# Altium schematic sheet Main.SchDoc
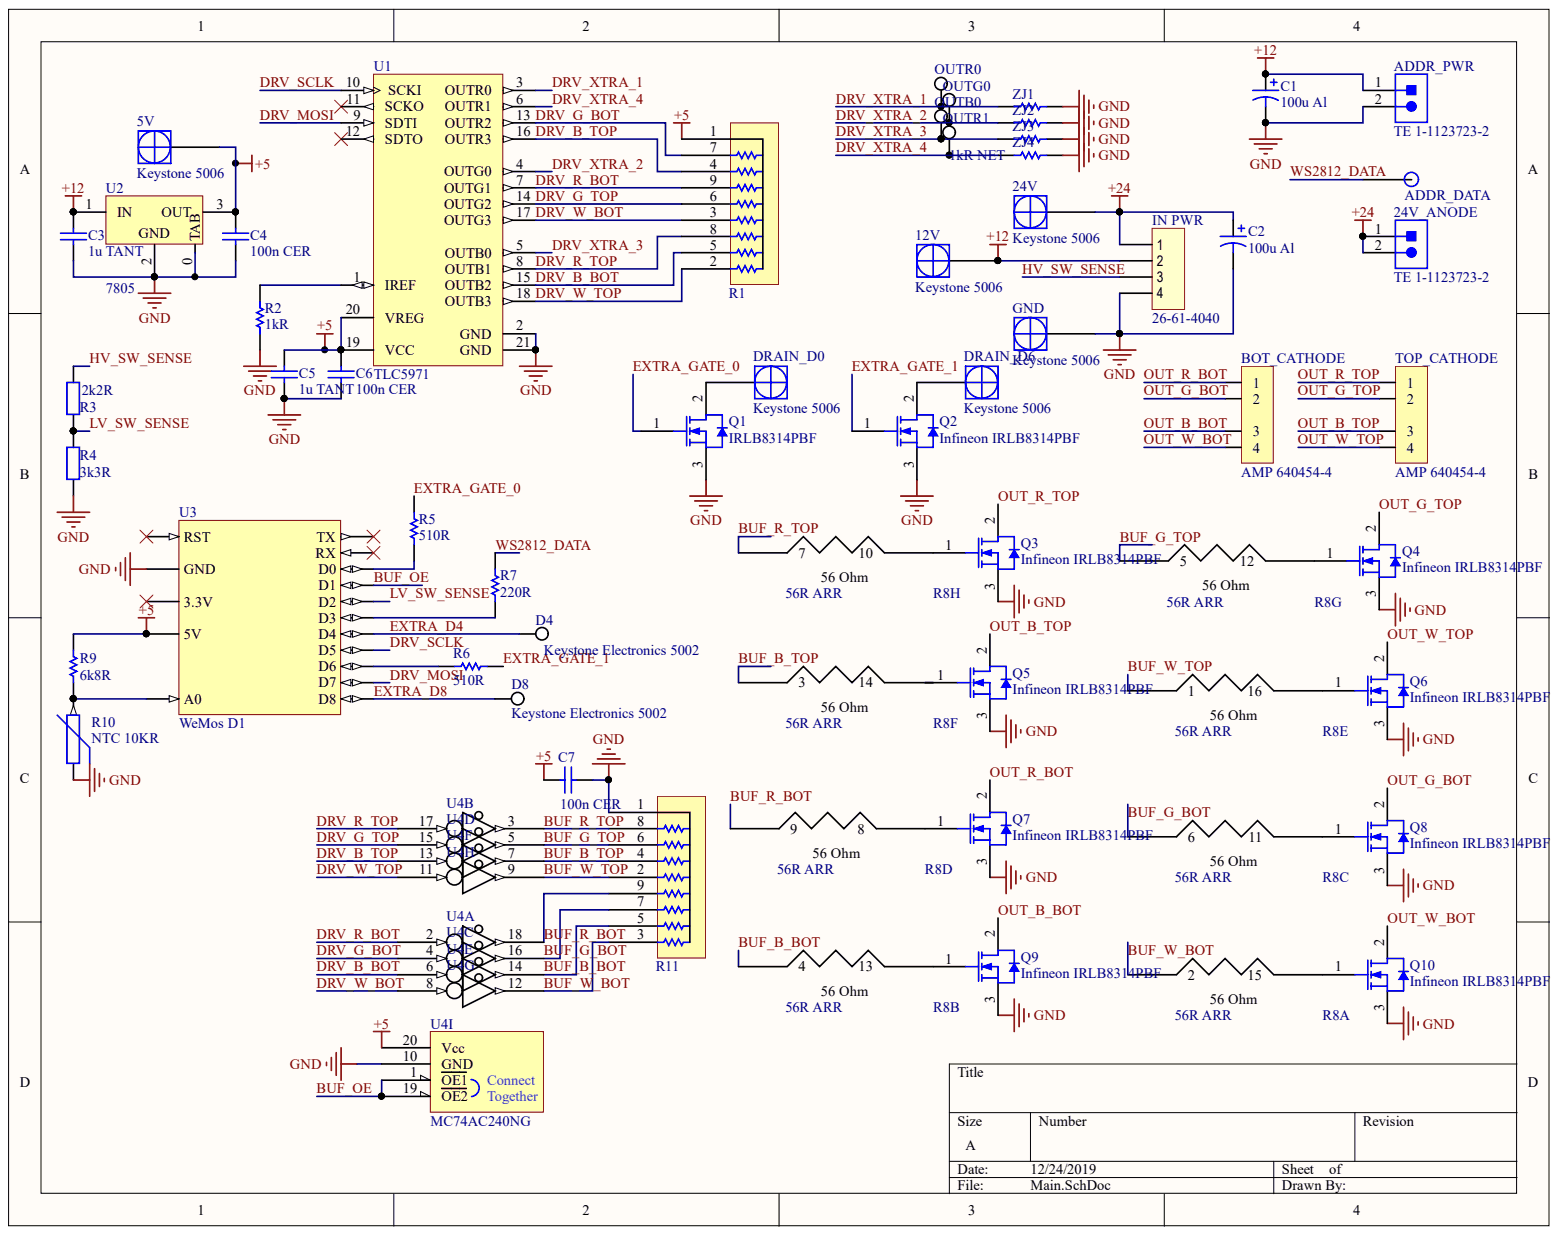
[diagram p0: Main.SchDoc]

## Parts
5V  Keystone 5006  Manufacturer Part Number: 5006  [PCB-kysbc4wefolcrxi0c60k-1]
12V  Keystone 5006  Manufacturer Part Number: 5006  [PCB-kysbc4wefolcrxi0c60k-1]
24V  Keystone 5006  Manufacturer Part Number: 5006  [PCB-kysbc4wefolcrxi0c60k-1]
24V_ANODE  TE 1-1123723-2  [1-1123723-2]
ADDR_DATA  Mill-Max 4357-0-00-15-00-00-03-0  [PCB-uwgrkp67w8sg7bsz95jd-1]  (value not drawn)
ADDR_PWR  TE 1-1123723-2  [1-1123723-2]
BOT_CATHODE  AMP 640454-4  Manufacturer Part Number: 640454-4  [PCBComponent_1]
C1  100u Al  [PCB-ccgi9bhgzt5wnre74bpr-1]
C2  100u Al  [PCB-ccgi9bhgzt5wnre74bpr-1]
C3  1u TANT  [PCB-123082-1]
C4  100n CER  [PCB-ql28fbuo3tond8refhb5-1]
C5  1u TANT  [PCB-123082-1]
C6  100n CER  [PCB-ql28fbuo3tond8refhb5-1]
C7  100n CER  [PCB-ql28fbuo3tond8refhb5-1]
D4  Keystone Electronics 5002  Manufacturer: Keystone  [TEST_LOOP]
D8  Keystone Electronics 5002  Manufacturer: Keystone  [TEST_LOOP]
DRAIN_D0  Keystone 5006  [PCB-kysbc4wefolcrxi0c60k-1]
DRAIN_D6  Keystone 5006  [PCB-kysbc4wefolcrxi0c60k-1]
GND  Keystone 5006  Manufacturer Part Number: 5006  [PCB-kysbc4wefolcrxi0c60k-1]
IN_PWR  26-61-4040  [PCB-nk55arxo024y8e91wffq-1]
OUTB0  (no value)  [TEST_LOOP]
OUTG0  (no value)  [TEST_LOOP]
OUTR0  (no value)  [TEST_LOOP]
OUTR1  (no value)  [TEST_LOOP]
Q1  IRLB8314PBF  [PCB-s29nlaacffy4qzcyhtz9-1]
Q2  Infineon IRLB8314PBF  [PCB-s29nlaacffy4qzcyhtz9-1]
Q3  Infineon IRLB8314PBF  [PCB-s29nlaacffy4qzcyhtz9-1]
Q4  Infineon IRLB8314PBF  [PCB-s29nlaacffy4qzcyhtz9-1]
Q5  Infineon IRLB8314PBF  [PCB-s29nlaacffy4qzcyhtz9-1]
Q6  Infineon IRLB8314PBF  [PCB-s29nlaacffy4qzcyhtz9-1]
Q7  Infineon IRLB8314PBF  [PCB-s29nlaacffy4qzcyhtz9-1]
Q8  Infineon IRLB8314PBF  [PCB-s29nlaacffy4qzcyhtz9-1]
Q9  Infineon IRLB8314PBF  [PCB-s29nlaacffy4qzcyhtz9-1]
Q10  Infineon IRLB8314PBF  [PCB-s29nlaacffy4qzcyhtz9-1]
R1  1kR NET  [PCB-g7s6cxysuoavmae2c56c-1]  (value not drawn)
R2  1kR  [PCB-bfjjg625tt0lscyu35rt-1]
R3  2K2R  [PCB-vee0c8q2g5btr8l8p592-1]
R4  3k3R  [PCB-mh9qeq81pbhpmiehun8l-1]
R5  510R  [PCB-2vcm05y6z7k0bu81myip-1]
R6  510R  [PCB-2vcm05y6z7k0bu81myip-1]
R7  220R  [PCB-heukol1j5k5ii1momt5n-1]
R8  56R ARR  [PCB-pr3f4dgy3c6tti4ikzhc]  (parts A,B,C,D,E,F,G,H)
R9  6k8R  [PCB-2039534-1]
R10  NTC 10KR  [PCB-newt7ey5aqhahc8c8q3h-1]
R11  10kR NET  [PCB-g7s6cxysuoavmae2c56c-1]  (value not drawn)
TOP_CATHODE  AMP 640454-4  Manufacturer Part Number: 640454-4  [PCBComponent_1]
U1  TLC5971  [TI-PWP20-2965X2290TP_N]
U2  7805  [PCBComponent_1 - duplicate]
U3  WeMos D1  [PCB-id05qy3v9mgzaped91p3-1]
U4  MC74AC240NG  [PCB-ssvtan231k94nl4fawkc-1]  (parts A,B,C,D,E,F,G,H,I)
ZJ1  Res1  [PCB-00vg8uwyzhdftjjrlpsr-1]  (value not drawn)
ZJ2  Res1  [PCB-00vg8uwyzhdftjjrlpsr-1]  (value not drawn)
ZJ3  Res1  [PCB-00vg8uwyzhdftjjrlpsr-1]  (value not drawn)
ZJ4  Res1  [PCB-00vg8uwyzhdftjjrlpsr-1]  (value not drawn)

## Nets
+5: 5V.1 C4.2 C5.2 C6.2 C7.2 R1.1 R9.2 U1.19(VCC) U1.20(VREG) U2.3(OUT) U3.9(5V) U4.20(Vcc)
+12: 12V.1 ADDR_PWR.1 C1.1 C3.2 IN_PWR.2 U2.1(IN)
+24: 24V.1 24V_ANODE.1 24V_ANODE.2 C2.1 IN_PWR.1
GND: ADDR_PWR.2 C1.2 C2.2 C3.1 C4.1 C5.1 C6.1 C7.1 GND.1 IN_PWR.4 Q1.3 Q2.3 Q3.3 Q4.3 Q5.3 Q6.3 Q7.3 Q8.3 Q9.3 Q10.3 R2.1 R4.1 R10.1 R11.1 U1.2(GND) U1.21(GND) U2.0(TAB) U2.2 U3.10(GND) U4.10(GND) ZJ1.2 ZJ2.2 ZJ3.2 ZJ4.2
BUF_B_BOT: R8.4 R11.5 U4.14
BUF_B_TOP: R8.3 R11.4 U4.7
BUF_G_BOT: R8.6 R11.7 U4.16
BUF_G_TOP: R8.5 R11.6 U4.5
BUF_OE: U3.14 U4.1(OE1) U4.19(OE2)
BUF_R_BOT: R8.8 R11.9 U4.18
BUF_R_TOP: R8.7 R11.8 U4.3
BUF_W_BOT: R8.2 R11.3 U4.12
BUF_W_TOP: R8.1 R11.2 U4.9
DRV_B_BOT: R1.5 U1.15(OUTB2) U4.6
DRV_B_TOP: R1.4 U1.16(OUTR3) U4.13
DRV_G_BOT: R1.7 U1.13(OUTR2) U4.4
DRV_G_TOP: R1.6 U1.14(OUTG2) U4.15
DRV_MOSI: U1.9(SDTI) U3.6(D7)
DRV_R_BOT: R1.9 U1.7(OUTG1) U4.2
DRV_R_TOP: R1.8 U1.8(OUTB1) U4.17
DRV_SCLK: U1.10(SCKI) U3.4(D5)
DRV_W_BOT: R1.3 U1.17(OUTG3) U4.8
DRV_W_TOP: R1.2 U1.18(OUTB3) U4.11
DRV_XTRA_1: OUTR0.TP U1.3(OUTR0) ZJ1.1
DRV_XTRA_2: OUTG0.TP U1.4(OUTG0) ZJ2.1
DRV_XTRA_3: OUTB0.TP U1.5(OUTB0) ZJ3.1
DRV_XTRA_4: OUTR1.TP U1.6(OUTR1) ZJ4.1
EXTRA_D4: D4.TP U3.11(D4)
EXTRA_D8: D8.TP U3.7(D8)
EXTRA_GATE_0: Q1.1 R5.1
EXTRA_GATE_1: Q2.1 R6.0
HV_SW_SENSE: IN_PWR.3 R3.2
LV_SW_SENSE: R3.1 R4.2 U3.13(D2)
OUT_B_BOT: BOT_CATHODE.3 Q9.2
OUT_B_TOP: Q5.2 TOP_CATHODE.3
OUT_G_BOT: BOT_CATHODE.2 Q8.2
OUT_G_TOP: Q4.2 TOP_CATHODE.2
OUT_R_BOT: BOT_CATHODE.1 Q7.2
OUT_R_TOP: Q3.2 TOP_CATHODE.1
OUT_W_BOT: BOT_CATHODE.4 Q10.2
OUT_W_TOP: Q6.2 TOP_CATHODE.4
WS2812_DATA: ADDR_DATA.1 R7.P$2
NetDRAIN_D0_1: DRAIN_D0.1 Q1.2
NetDRAIN_D6_1: DRAIN_D6.1 Q2.2
NetQ3_1: Q3.1 R8.10
NetQ4_1: Q4.1 R8.12
NetQ5_1: Q5.1 R8.14
NetQ6_1: Q6.1 R8.16
NetQ7_1: Q7.1 R8.9
NetQ8_1: Q8.1 R8.11
NetQ9_1: Q9.1 R8.13
NetQ10_1: Q10.1 R8.15
NetR2_2: R2.2 U1.1(IREF)
NetR5_0: R5.0 U3.3(D0)
NetR6_1: R6.1 U3.5(D6)
NetR7_P$1: R7.P$1 U3.12(D3)
NetR9_1: R9.1 R10.2 U3.2(A0)

Not connected: U1 11(SCKO) 12(SDTO); U3 1(RST) 8(3.3V) 15(RX) 16(TX)

## Library data of the parts
5V 12V 24V GND = CMP-eb34223ee69c34f3-10: Generic Test point; Color: Black; Component Kind: Standard; Library Name: CommunityVault; Octopart URL: https://octopart.com/5006-keystone-10446
24V_ANODE ADDR_PWR = CMP-b1307a6381eb6ef7-3: Conn Wire to Board HDR 2 POS 3.96mm Solder ST Thru-Hole Automotive Bag; Component Kind: Standard; Library Name: CommunityVault
ADDR_DATA = CMP-1a86d7d20b3dc541-1: Circuit Board Hardware - PCB Solder Mount PCB Pin; Lead-Free Status: Contains Lead; Component Kind: Standard; Library Name: CommunityVault; Manufacturer Part Number: 4357-0-00-15-00-00-03-0; OctopartID: 1a86d7d20b3dc541; Octopart URL: https://octopart.com/4357-0-00-15-00-00-03-0-mill-max-73282781; RoHS: Compliant
BOT_CATHODE TOP_CATHODE = CMP-4e5485075a289193-4: MTA-100 4 Position 2.54 mm Single Row Through-Hole Straight Wire to Board Header; Current Rating: 4.00 A; ELV Compliant: Compliant; Gender: Male; Lead-Free Status: Lead Free; Mounting Angle: 180 °; Number of Contacts: 4; Pin Pitch: 2.54 mm; Shrouded: No; Voltage Rating (AC): 250 V; Component Kind: Standard; Contact Material: Copper Alloy; Contact Plating: Tin; Flammability Rating: UL 94 V-0; Housing Color: Natural; Housing Material: Polyester; Library Name: CommunityVault; Lifecycle Status: Active; Mounting Style: Through Hole, Vertical; Number of Positions: 4; Number of Rows: 1; OctopartID: 4e5485075a289193; Octopart URL: http://octopart.com/640454-4-te+connectivity+%2F+amp-39399706; Orientation: Vertical; Packaging: Bag; REACH SVHC Compliance: No SVHC; RoHS: Compliant; Size-Height: 7.87 mm; Size-Length: 10.2 mm; Size-Width: 5.71 mm
C1 C2 = CMP-c5c50cbd69471a02-1: Cap Aluminum Lytic 100uF 50V 20% (8 X 11.5mm) Radial 3.5mm 870mA 3000h 105C Bulk; Manufacturer Part Number: EEUFM1H101; Capacitance: 100 F; Dielectric Material: Aluminum Electrolytic; Lead-Free Status: Lead Free; Lead Length: 17.0 mm; Voltage Rating (DC): 50.0 V; Capacitance Tolerance: ±20%; Component Kind: Standard; Library Name: CommunityVault; Lifecycle Status: Not Listed by Manufacturer; Mounting Style: Through Hole; Number of Pins: 2; OctopartID: c5c50cbd69471a02; Octopart URL: http://octopart.com/eeufm1h101-panasonic-7506404; Packaging: Bulk; REACH SVHC Compliance: No SVHC; RoHS: Compliant; Size-Diameter: 8.00 mm; Size-Height: 11.5 mm; Size-Length: 11.5 mm
C3 C5 = CMP-b0db79df53a46f1c-1: Cap Tant Solid 1uF 35V 20% (4.5 X 7.1mm) Radial 2.54mm 125 C Bulk; Manufacturer Part Number: T350A105M035AT; Capacitance: 1.00 µF; Dielectric Material: Tantalum; Equivalent Series Resistance (ESR): 8.00 ?; Voltage Rating (DC): 35.0 V; Capacitance Tolerance: ±20%; Component Kind: Standard; Library Name: FavoritesLibrary; Mounting Style: Through Hole, Radial; Number of Pins: 2; OctopartID: b0db79df53a46f1c; Octopart URL: https://octopart.com/t350a105m035at-kemet-968217; Operating Temperature: -55.0 °C (min); Packaging: Cut Tape (CT); RoHS: Compliant
C4 C6 C7 = CMP-1272d9258f2acdb5-7: K 100nF Ceramic Multilayer Capacitor, 50 V dc, +125degC, X7R Dielectric, +/-10%; Component Kind: Standard; Library Name: CommunityVault
D4 D8 = CMP-954155-7: KEYSTONE   5002   Series:-; Manufacturer Part Number: 5002; Color: White; Lead-Free Status: Lead Free; Component Kind: Standard; Contact Material: Phosphor Bronze; Contact Plating: Tin; Library Name: CommunityVault; OctopartID: f34a3a40c2652c8d; Octopart Url: https://octopart.com/5002-keystone-5193; Packaging: Bag; REACH SVHC Compliance: No SVHC; RoHS: Compliant; Size-Diameter: 2.54 mm; Size-Height: 7.62 mm; Size-Inner Diameter: 1.27 mm; Size-Length: 7.62 mm
DRAIN_D0 DRAIN_D6 = CMP-eb34223ee69c34f3-10: Generic Test point; Manufacturer Part Number: 5006; Color: Black; Component Kind: Standard; Library Name: CommunityVault; Octopart URL: https://octopart.com/5006-keystone-10446
IN_PWR = CMP-5db694b845698271-1: Connector Wire to Board Header 4 Position 3.96mm Solder Straight Thru-Hole Bag; Current Rating: 7.00 A; Gender: Male; Insulation Resistance: 50.0 GΩ; Number of Circuits: 4; Component Kind: Standard; Contact Material: Brass; Halogen Free Status: Not Low Halogen; Housing Color: Natural; Housing Material: Polyester; Library Name: CommunityVault; Lifecycle Status: Active; Manufacturer Part Number: 26-61-4040; Number of Contacts: 4; Octopart URL: http://octopart.com/26-61-4040-molex-277945; Orientation: Vertical; Packaging: Bulk; Size-Height: 11.3 mm; Size-Length: 15.7 mm; Size-Width: 10.0 mm
OUTB0 OUTG0 OUTR0 OUTR1 = CMP-954155-7: KEYSTONE   5002   Series:-; Manufacturer Part Number: 5002; Lead-Free Status: Lead Free; Color: White; Component Kind: Standard; Contact Material: Phosphor Bronze; Contact Plating: Tin; Library Name: CommunityVault; Manufacturer: Keystone; OctopartID: f34a3a40c2652c8d; Octopart Url: https://octopart.com/5002-keystone-5193; Packaging: Bag; REACH SVHC Compliance: No SVHC; RoHS: Compliant; Size-Diameter: 2.54 mm; Size-Height: 7.62 mm; Size-Inner Diameter: 1.27 mm; Size-Length: 7.62 mm
Q1-Q10 = CMP-b9737394b7fb66b4-2: Trans MOSFET N-CH 30V 171A 3-Pin(3+Tab) TO-220AB Tube; Component Kind: Standard; Library Name: CommunityVault
R1 = CMP-cd9a049ffc2d125d-1: Res Thick Film NET 1K Ohm 2% 1.13W ±100ppm/°C BUS Conformal 9-Pin SIP Pin Thru-Hole Bulk; Manufacturer Part Number: 4609X-101-102LF; Insulation Resistance: 10.0 M?; Lead Length: 3.43 mm; Resistance: 1.00 k?; Temperature Coefficient: -100 ppm/°C to 100 ppm/°C; Voltage Rating (DC): 100 V; Case/Package: SIP; Component Kind: Standard; Library Name: CommunityVault; Mounting Style: Through Hole; Number of Pins: 9; OctopartID: cd9a049ffc2d125d; Octopart URL: https://octopart.com/4609x-101-102lf-bourns-988369; Operating Temperature: -55.0 °C to 125 °C; Power Rating: 1.13 W; REACH SVHC Compliance: No SVHC; Resistance Tolerance: ±2%; RoHS: Compliant
R2 = CMP-db3e1311f37e04f9-1: Res Carbon Film 1K Ohm 5% 1/4W -400ppm/°C to 0ppm/°C Conformal AXL Thru-Hole T/R; Manufacturer Part Number: CF14JT1K00; Lead-Free Status: Lead Free; Component Kind: Standard; Library Name: CommunityVault; Mounting Style: Axial, Through Hole; OctopartID: db3e1311f37e04f9; Octopart URL: http://octopart.com/cf14jt1k00-stackpole+electronics-19205414; Packaging: Tape & Reel (TR); Resistance Tolerance: ±5%; RoHS: Compliant
R3 = CMP-5fe496ee22e78023-2: Resistor; Carbon Composition; Res 2.2 Kilohms; Pwr-Rtg 0.25 W; Tol 5%; Axial; : 2k2R; Component Kind: Standard; Library Name: CommunityVault; Octopart URL: http://octopart.com/od222je-ohmite-22629
R4 = CMP-c22d0bd2b6121dea-3: Through Hole Carbon Film Resistor, CFR Series, 3.3 kohm, 250 mW, - 5%, 200 V, Axial Leaded; Manufacturer Part Number: CFR16J3K3; ELV Compliant: Compliant; Power Rating: 250 mW; Component Kind: Standard; Library Name: CommunityVault; OctopartID: c22d0bd2b6121dea
R5 R6 = CMP-4075885a68979fb2-1: Res Carbon Film 510 Ohm 5% 0.25W(1/4W) -400ppm/ to 0ppm/ Conformal Coated AXL T/R; Lead-Free Status: Lead Free; Component Kind: Standard; Contact Plating: Tin over Copper; Library Name: CommunityVault; Mounting Style: Axial; Octopart URL: https://octopart.com/cf14jt510r-stackpole+electronics-19205508; Resistance Tolerance: ±5%
R7 = CFR16J220R: Through Hole Carbon Film Resistor, CFR Series, 220 ohm, 250 mW, - 5%, 200 V, Axial Leaded; Component Kind: Standard; Library Name: Octopart library
R8 = CMP-6860a164b1e4b7a7-3: Res Thick Film NET 56 Ohm 2% 2.25W ±100ppm/°C ISOL Molded 16-Pin DIP Pin Thru-Hole; Component Kind: Standard; Library Name: CommunityVault
R9 = CMP-249cecb3582dfb5b-1: RNF Series 0.25 W 68.1 kOhm ±1 % ±100 ppm/°C General Purpose Metal Film Resistor; Manufacturer Part Number: RNF14FTD68K1; Lead-Free Status: Lead Free; Power Rating: 250 mW; Resistance: 68.1k; Resistance Tolerance: ±1%; Component Kind: Standard; Library Name: CommunityVault; Mounting Style: Axial, Through Hole; OctopartID: 249cecb3582dfb5b; Octopart URL: http://octopart.com/rnf14ftd68k1-stackpole+electronics-19225047; Packaging: Tape & Reel (TR); RoHS: Compliant
R10 = CMP-b6ae7d0058556969-1: Thermistor NTC 10K Ohm 2.2% 2-Pin Wire 3984K -4.5 to -4 Box Automotive; Resistance: 10.0 kΩ; Component Kind: Standard; Library Name: CommunityVault; Mounting Style: Through Hole; Number of Pins: 2; Octopart URL: https://octopart.com/ntcle305e4103sb-vishay-44354461; Operating Temperature: -40.0 °C to 125 °C; REACH SVHC Compliance: No SVHC; RoHS: Compliant
R11 = CMP-2564ec6c1ab8faa3-4: Res Thick Film NET 10K Ohm 2% 1.13W }100ppm/ BUS Conformal Coated 9-Pin SIP Pin Thru-Hole Bulk; Manufacturer Part Number: 4609X-101-103LF; Insulation Resistance: 10.0 MΩ; Lead Length: 3.43 mm; Resistance: 10.0 kΩ; Voltage Rating (DC): 100 V; Case/Package: SIP; Component Kind: Standard; Library Name: CommunityVault; Mounting Style: Through Hole; Number of Pins: 9; OctopartID: 2564ec6c1ab8faa3; Octopart URL: http://octopart.com/4609x-101-103lf-bourns-137914; Power Rating: 1.13 W; REACH SVHC Compliance: No SVHC; Resistance Tolerance: ±2%; RoHS: Compliant
U1 = CMP-1427133-1: 12-Channel, 16-Bit ES-PWM RGB LED Driver with 3.3 V Linear Regulator, 6 to 17 V, -40 to 85 degC, 20-pin SOP (PWP20), Green (RoHS & no Sb/Br); Constant Current: Yes; Frequency: 6MHz ~ 12MHz; Number of Outputs: 12; Other Names: 296-27796-1; Topology: Linear, PWM; Type - Primary: General Purpose; Type - Secondary: Color, RGB; Voltage - Output: 17V; Voltage - Supply: 3 V ~ 5.5 V, 6 V ~ 17 V; Category: Integrated Circuits (ICs); CiivaID: 1427133; Ciiva URL: https://ciiva.com/part/TLC5971PWPR-1427133; Component Kind: Standard; Constant Voltage: -; Dynamic Catalog: Linear; Family: PMIC - LED Drivers; Internal Driver: Yes; Library Name: CommunityVault; Mounting Type: Surface Mount; Operating Temperature: -40°C ~ 85°C; Package / Case: 20-TSSOP (0.173", 4.40mm Width) Exposed Pad; Packaging: Cut Tape (CT); Series: -; Standard Package: 1; Supplier Device Package: 20-HTSSOP
U2 = CMP-1170713-22: L7805 Series 1.5 A 5 V Three Terminal Voltage Regulator - TO-220; Manufacturer Part Number: L7805ACV; Number of Outputs: 1; Case/Package: TO-220; Component Kind: Standard; Library Name: CommunityVault; Mounting Style: Through Hole
U3 = CMP-0c15c0cc3e63d3d2-1: IZOKEE D1 Mini NodeMcu Lua 4M Bytes WLAN WiFi Internet Development Board Base on ESP8266 ESP-12F for Arduino, 100%...; Manufacturer Part Number: A076F53A6S; Component Kind: Standard; Library Name: CommunityVault; OctopartID: 0c15c0cc3e63d3d2; Octopart URL: https://octopart.com/a076f53a6s-generic-97720080
U4 = CMP-05c8ccd75635da0f-3: Buffer/Line Driver 8-CH Inverting 3-ST CMOS Automotive 20-Pin PDIP Tube; Lead-Free Status: Lead Free; Number of Bits: 8; Quiescent Current: 8.00 µA (max); Supply Voltage (DC): 6.00 V (max); Component Kind: Standard; Library Name: FavoritesLibrary; Lifecycle Status: Not Listed by Manufacturer; Manufacturer Part Number: MC74AC240NG; Mounting Style: Through Hole; Number of Pins: 20; OctopartID: 05c8ccd75635da0f; Octopart URL: https://octopart.com/mc74ac240ng-on+semiconductor-1406619; Operating Temperature: 85.0 °C (max); Packaging: Tube, Rail; RoHS: Compliant
ZJ1-ZJ4 = CMP-1845929-13: RES SMD 0 OHM JUMPER 1/10W 0603; Manufacturer Part Number: ERJ-3GEY0R00V; Vendor Part Number: P0.0GCT-ND; Power (Watts): 0.1W, 1/10W; Resistance: 0 Ohms; Vendor: Digikey; Component Kind: Standard; Composition: Thick Film; Cost: 0.10; Features: Automotive AEC-Q200; Height - Seated (Max): 0.022" (0.55mm); HelpURL: https://industrial.panasonic.com/ww/products/resistors/chip-resistors/general-purpose-chip-resistors/thick-film-chip-resistors/ERJ3GEY0R00V; Library Name: CommunityVault; OctopartID: fc8b36ccf5db234c; Octopart URL: http://octopart.com/erj-3gey0r00v-panasonic-55389238; Package / Case: 0603 (1608 Metric); Series: ERJ; Size / Dimension: 0.063" L x 0.032" W (1.60mm x 0.80mm); Supplier Device Package: 0603; Tolerance: Jumper
